# Altium schematic sheet 1. Nano&EEPROM.SchDoc
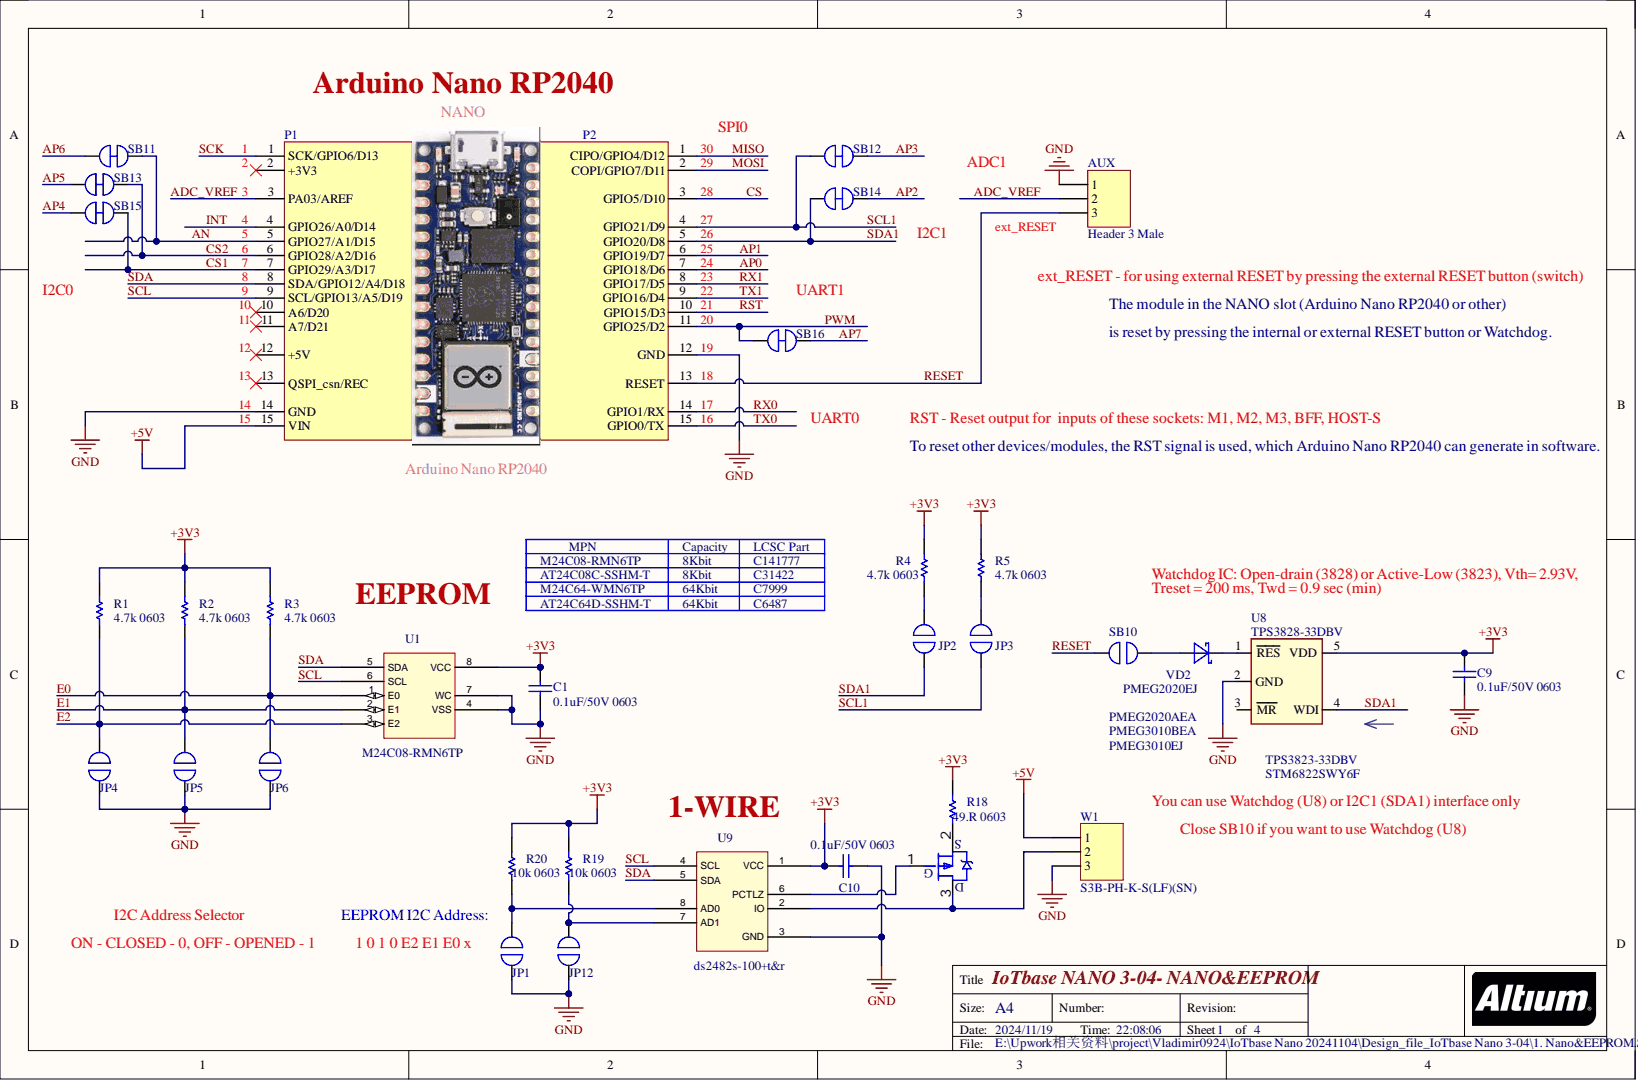
[diagram p0: 1. Nano&EEPROM.SchDoc]

## Parts
AUX  Header 3 Male  [CON_1X03_2.54MM_MALE_STRAIGHT]
C1  0.1uF/50V 0603  [CAPC1608X100N]
C9  0.1uF/50V 0603  [CAPC1608X100N]
C10  0.1uF/50V 0603  [CAPC1608X100N]
JP1  SOLDER_JUMPER_NO  [SOLDER_JUMPER_NO_2]  (value not drawn)  (no BOM)
JP2  SOLDER_JUMPER_NO  [SOLDER_JUMPER_NO_2]  (value not drawn)  (no BOM)
JP3  SOLDER_JUMPER_NO  [SOLDER_JUMPER_NO_2]  (value not drawn)  (no BOM)
JP4  SOLDER_JUMPER_NO  [SOLDER_JUMPER_NO_2]  (value not drawn)  (no BOM)
JP5  SOLDER_JUMPER_NO  [SOLDER_JUMPER_NO_2]  (value not drawn)  (no BOM)
JP6  SOLDER_JUMPER_NO  [SOLDER_JUMPER_NO_2]  (value not drawn)  (no BOM)
JP12  SOLDER_JUMPER_NO  [SOLDER_JUMPER_NO_2]  (value not drawn)  (no BOM)
P1  (no value)  [PBS-15]
P2  (no value)  [PBS-15]
Q1  BSS84W-7-F  [SC-70-3(SOT323)]  (value not drawn)
R1  4.7k 0603  [RESC1608X50N]
R2  4.7k 0603  [RESC1608X50N]
R3  4.7k 0603  [RESC1608X50N]
R4  4.7k 0603  [RESC1608X50N]
R5  4.7k 0603  [RESC1608X50N]
R18  49.R 0603  [RESC1608X50N]
R19  10k 0603  [RESC1608X50N]
R20  10k 0603  [RESC1608X50N]
SB10  SOLDER_JUMPER_NO  [SOLDER_JUMPER_NO_2]  (value not drawn)  (no BOM)
SB11  SOLDER_JUMPER_NC  [SOLDER_JUMPER_NC]  (value not drawn)  (no BOM)
SB12  SOLDER_JUMPER_NC  [SOLDER_JUMPER_NC]  (value not drawn)  (no BOM)
SB13  SOLDER_JUMPER_NC  [SOLDER_JUMPER_NC]  (value not drawn)  (no BOM)
SB14  SOLDER_JUMPER_NC  [SOLDER_JUMPER_NC]  (value not drawn)  (no BOM)
SB15  SOLDER_JUMPER_NC  [SOLDER_JUMPER_NC]  (value not drawn)  (no BOM)
SB16  SOLDER_JUMPER_NC  [SOLDER_JUMPER_NC]  (value not drawn)  (no BOM)
U1  M24C08-RMN6TP  [SOIC127P600X175-8N]
U8  TPS3828-33DBV  [SOT23-5 realistic]
U9  ds2482s-100+t&r  [SOIC127P600X175-8N]
VD2  PMEG2020EJ  [SOD323_uni]
W1  S3B-PH-K-S(LF)(SN)  [CONN-TH_3P-P2.00_S3B-PH-K-S-LF-SN]

## Nets
+3V3: C1.2 C9.2 C10.2 R1.1 R2.1 R3.1 R4.1 R5.1 R18.1 R19.1 R20.1 U1.8(VCC) U8.5(VDD) U9.1(VCC)
+5V: P1.15(VIN) W1.1
GND: AUX.1 C1.1 C9.1 C10.1 JP1.2 JP4.2 JP5.2 JP6.2 JP12.2 P1.14(GND) P2.12(GND) U1.4(VSS) U1.7(WC) U8.2(GND) U9.3(GND) W1.3
ADC_VREF: AUX.2 P1.3(PA03/AREF)
AN: P1.5(GPIO27/A1/D15) SB11.2
AP0: P2.7(GPIO18/D6)
AP1: P2.6(GPIO19/D7)
AP2: SB14.2
AP3: SB12.2
AP4: SB15.1
AP5: SB13.1
AP6: SB11.1
AP7: SB16.2
CS: P2.3(GPIO5/D10)
CS1: P1.7(GPIO29/A3/D17) SB15.2
CS2: P1.6(GPIO28/A2/D16) SB13.2
E0: JP6.1 R3.2 U1.1(E0)
E1: JP5.1 R2.2 U1.2(E1)
E2: JP4.1 R1.2 U1.3(E2)
INT: P1.4(GPIO26/A0/D14)
MISO: P2.1(CIPO/GPIO4/D12)
MOSI: P2.2(COPI/GPIO7/D11)
PWM: P2.11(GPIO25/D2) SB16.1
RESET: AUX.3 P2.13(RESET) SB10.2
RST: P2.10(GPIO15/D3)
RX0: P2.14(GPIO1/RX)
RX1: P2.8(GPIO17/D5)
SCK: P1.1(SCK/GPIO6/D13)
SCL: P1.9(SCL/GPIO13/A5/D19) U1.6(SCL) U9.4(SCL)
SCL1: JP3.2 P2.4(GPIO21/D9) SB12.1
SDA: P1.8(SDA/GPIO12/A4/D18) U1.5(SDA) U9.5(SDA)
SDA1: JP2.2 P2.5(GPIO20/D8) SB14.1 U8.4(WDI)
TX0: P2.15(GPIO0/TX)
TX1: P2.9(GPIO16/D4)
NetJP1_1: JP1.1 R20.2 U9.8(AD0)
NetJP2_1: JP2.1 R4.2
NetJP3_1: JP3.1 R5.2
NetJP12_1: JP12.1 R19.2 U9.7(AD1)
NetQ1_1: Q1.1 U9.6(PCTLZ)
NetQ1_2: Q1.2 R18.2
NetQ1_3: Q1.3 U9.2(IO) W1.2
NetSB10_1: SB10.1 VD2.1
NetU8_1: U8.1(RES) VD2.2

Not connected: P1 2(+3V3) 10(A6/D20) 11(A7/D21) 12(+5V) 13(QSPI_csn/REC); U8 3(MR)

## Library data of the parts
AUX = Header 3: Gold 250V 3A Straight Square Pins 2.54mm 3P 260℃ 6mm -40℃~+105℃ 3mm 2.54mm Brass Black Plugin 2.54mm 1x3P Plugin,P=2.54mm Pin Headers ROHS; Manufacturer: Hanbo Electronic; Manufacturer Part Number: HB-PH3-25413PB2GOP; Component Kind: Standard; Library Name: Miscellaneous Connectors.IntLib; Supplier 1: LCSC; Supplier Part Number 1: C6332196
C1 C9 C10 = Cap: 50V 100nF X7R ±10% 0603 Multilayer Ceramic Capacitors MLCC - SMD/SMT ROHS; Manufacturer: Walsin Tech Corp; Manufacturer Part Number: 0603B104K500CT; Component Kind: Standard; Library Name: Miscellaneous Devices.IntLib; Signal Integrity: Cap; Simulation: CAP; Supplier 1: LCSC; Supplier Part Number 1: C314282; Value: 0.1uF/50V 0603
JP1-JP6 JP12 SB10 = SOLDER_JUMPER_NO: Solder Jumper; Library Name: Schematic_Lib.SchLib
P1 = Header 15_L: 2.54mm Plugin 15P Brass Straight 1x15P Plugin,P=2.54mm Female Headers ROHS; Manufacturer: JILN; Manufacturer Part Number: KH-2.54FH-1X15P-H8.5; Component Kind: Standard; Signal Integrity: Connector; Supplier 1: LCSC; Supplier Part Number 1: C2932676; Library Name: IoTbase PICO 3-04.SCHLIB
P2 = Header 15_R: 2.54mm Plugin 15P Brass Straight 1x15P Plugin,P=2.54mm Female Headers ROHS; Manufacturer: JILN; Manufacturer Part Number: KH-2.54FH-1X15P-H8.5; Component Kind: Standard; Signal Integrity: Connector; Supplier 1: LCSC; Supplier Part Number 1: C2932676; Library Name: IoTbase PICO 3-04.SCHLIB
Q1 = BSS84W-7-F: MOSFET P-CH 50V 130MA SOT23-3; Manufacturer: DIODES(美台); Add into BOM: yes; Drain Source On Resistance (RDS(on)@Vgs,Id): 10Ω@5V,100mA; Gate Threshold Voltage (Vgs(th)@Id): 2V@1mA; Input Capacitance (Ciss@Vds): 45pF@25V; Power Dissipation (Pd): 200mW; Type: P沟道; Component Kind: Standard; Continuous Drain Current (Id): 130mA; Convert to PCB: yes; Datasheet: https://atta.szlcsc.com/upload/public/pdf/source/20171221/C155254_15138396176161195998.pdf; Device: BSS84W-7-F; Drain Source Voltage (Vdss): 50V; JLCPCB Part Class: Extended Part; LCSC Part Name: P沟道 耐压:50V 电流:130mA; Manufacturer Part: BSS84W-7-F; Operating Temperature: -55℃~+150℃@(Tj); Supplier: LCSC; Supplier 1: LCSC; Supplier Footprint: SOT-323; Supplier Part: C110490; Supplier Part Number 1: C110490; Symbol: BSS84W-7-F; Library Name: IoTbase PICO 3-04.SCHLIB
R1-R5 R18-R20 = Res1: 100mW Thick Film Resistors ±100ppm/℃ ±1% 4.7kΩ 0603 Chip Resistor - Surface Mount ROHS; Manufacturer: UNI-ROYAL(Uniroyal Elec); Manufacturer Part Number: 0603WAF4701T5E; Component Kind: Standard; Library Name: Miscellaneous Devices.IntLib; Supplier 1: LCSC; Supplier Part Number 1: C23162
  R1-R5: Value: 4.7k 0603
  R18: Value: 49.R 0603
  R19 R20: Value: 10k 0603
SB11-SB16 = SOLDER_JUMPER_NC: Solder Jumper; Library Name: Schematic_Lib.SchLib
U1 = M34E02-FMC6TG: EEPROM  IC 8Kb I2C 400 kHz 900 ns 8-SOIC; Manufacturer: STMicroelectronics; Manufacturer Part Number: M24C64-FMC6TG; Component Kind: Standard; Supplier 1: LCSC; Supplier Part Number 1: C141777; Library Name: IoTbase PICO 3-03.SCHLIB
U9 = ds2482s-100+t&r: IC I2C TO 1WIRE BRIDGE 8SOIC; Manufacturer: STMicroelectronics; Manufacturer Part Number: M24C64-FMC6TG; Component Kind: Standard; Supplier 1: LCSC; Supplier Part Number 1: C141777; Library Name: IoTbase PICO 3-04.SCHLIB
VD2 = PMEG2020EJ: Shottky diode SOD323; Component Kind: Standard; Library Name: BTGV6_0814.SchLib; Value: PMEG2020EJ
W1 = Header 3: S3B-PH,; Manufacturer: Hanbo Electronic; Component Kind: Standard; Library Name: Miscellaneous Connectors.IntLib; Manufacturer Part Number: S3B-PH-K-S(LF)(SN); Supplier 1: LCSC; Supplier Part Number 1: C157929
U8 = TPS3828-33DBV: Component Kind: Standard; Library Name: BTGV6_0814.SchLib; Value: TPS3828-33DBV
